# Altium schematic sheet Processor.SchDoc(Processor)
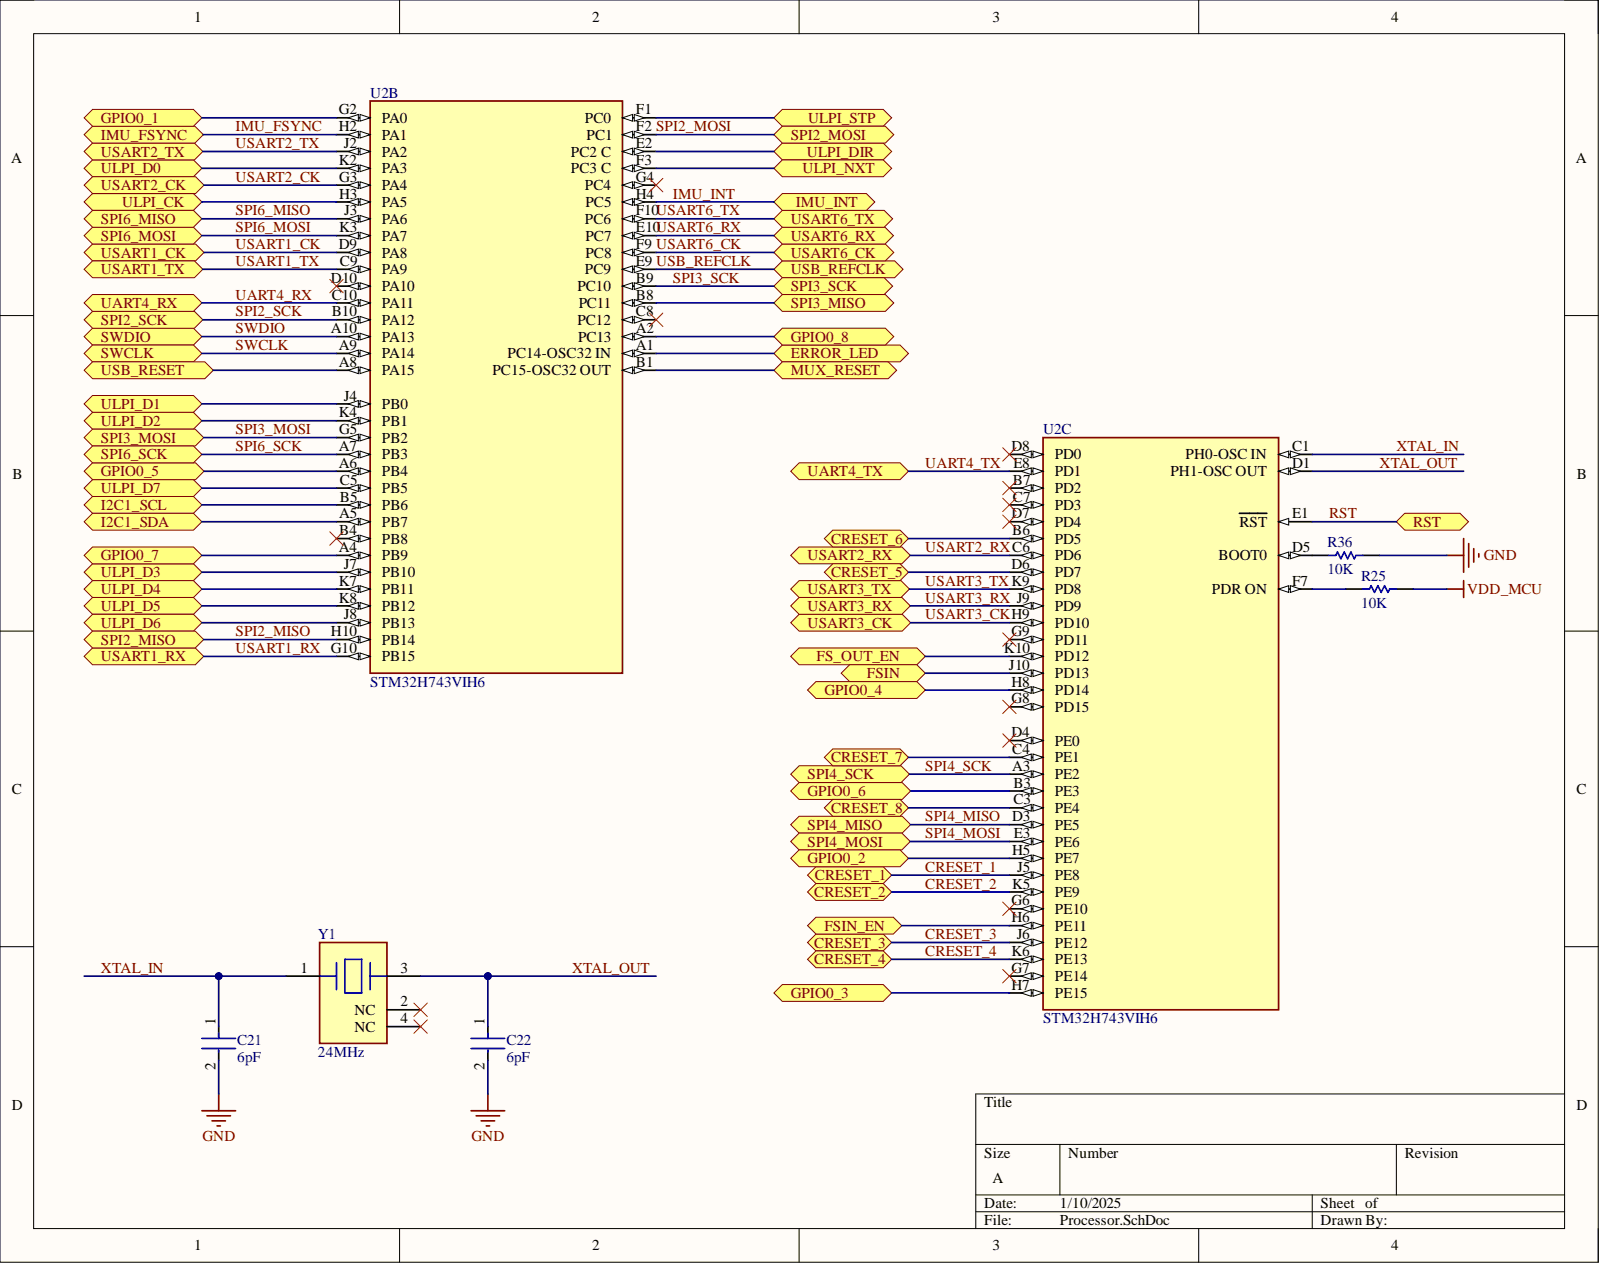
[diagram p0: Processor.SchDoc]

## Parts
C21  6pF
C22  6pF
R25  10K
R36  10K
U2  STM32H743VIH6  (parts B,C)
Y1  24MHz

## Ports
CRESET_1, CRESET_2, CRESET_3, CRESET_4, CRESET_5, CRESET_6, CRESET_7, CRESET_8, ERROR_LED, FS_OUT_EN, FSIN, FSIN_EN, GPIO0_1, GPIO0_2, GPIO0_3, GPIO0_4, GPIO0_5, GPIO0_6, GPIO0_7, GPIO0_8, I2C1_SCL, I2C1_SDA, IMU_FSYNC, IMU_INT, MUX_RESET, RST, SPI2_MISO, SPI2_MOSI, SPI2_SCK, SPI3_MISO, SPI3_MOSI, SPI3_SCK, SPI4_MISO, SPI4_MOSI, SPI4_SCK, SPI6_MISO, SPI6_MOSI, SPI6_SCK, SWCLK, SWDIO, UART4_RX, UART4_TX, ULPI_CK, ULPI_D0, ULPI_D1, ULPI_D2, ULPI_D3, ULPI_D4, ULPI_D5, ULPI_D6, ULPI_D7, ULPI_DIR, ULPI_NXT, ULPI_STP, USART1_CK, USART1_RX, USART1_TX, USART2_CK, USART2_RX, USART2_TX, USART3_CK, USART3_RX, USART3_TX, USART6_CK, USART6_RX, USART6_TX, USB_REFCLK, USB_RESET

## Nets
GND: C21.2 C22.2 R36.1
VDD_MCU: R25.1
CRESET_1: U2.J5(PE8) ; port CRESET_1
CRESET_2: U2.K5(PE9) ; port CRESET_2
CRESET_3: U2.J6(PE12) ; port CRESET_3
CRESET_4: U2.K6(PE13) ; port CRESET_4
CRESET_5: U2.D6(PD7) ; port CRESET_5
CRESET_6: U2.B6(PD5) ; port CRESET_6
CRESET_7: U2.C4(PE1) ; port CRESET_7
CRESET_8: U2.C3(PE4) ; port CRESET_8
ERROR_LED: U2.A1(PC14-OSC32_IN) ; port ERROR_LED
FS_OUT_EN: U2.K10(PD12) ; port FS_OUT_EN
FSIN: U2.J10(PD13) ; port FSIN
FSIN_EN: U2.H6(PE11) ; port FSIN_EN
GPIO0_1: U2.G2(PA0) ; port GPIO0_1
GPIO0_2: U2.H5(PE7) ; port GPIO0_2
GPIO0_3: U2.H7(PE15) ; port GPIO0_3
GPIO0_4: U2.H8(PD14) ; port GPIO0_4
GPIO0_5: U2.A6(PB4) ; port GPIO0_5
GPIO0_6: U2.B3(PE3) ; port GPIO0_6
GPIO0_7: U2.A4(PB9) ; port GPIO0_7
GPIO0_8: U2.A2(PC13) ; port GPIO0_8
I2C1_SCL: U2.B5(PB6) ; port I2C1_SCL
I2C1_SDA: U2.A5(PB7) ; port I2C1_SDA
IMU_FSYNC: U2.H2(PA1) ; port IMU_FSYNC
IMU_INT: U2.H4(PC5) ; port IMU_INT
MUX_RESET: U2.B1(PC15-OSC32_OUT) ; port MUX_RESET
RST: U2.E1(RST) ; port RST
SPI2_MISO: U2.H10(PB14) ; port SPI2_MISO
SPI2_MOSI: U2.F2(PC1) ; port SPI2_MOSI
SPI2_SCK: U2.B10(PA12) ; port SPI2_SCK
SPI3_MISO: U2.B8(PC11) ; port SPI3_MISO
SPI3_MOSI: U2.G5(PB2) ; port SPI3_MOSI
SPI3_SCK: U2.B9(PC10) ; port SPI3_SCK
SPI4_MISO: U2.D3(PE5) ; port SPI4_MISO
SPI4_MOSI: U2.E3(PE6) ; port SPI4_MOSI
SPI4_SCK: U2.A3(PE2) ; port SPI4_SCK
SPI6_MISO: U2.J3(PA6) ; port SPI6_MISO
SPI6_MOSI: U2.K3(PA7) ; port SPI6_MOSI
SPI6_SCK: U2.A7(PB3) ; port SPI6_SCK
SWCLK: U2.A9(PA14) ; port SWCLK
SWDIO: U2.A10(PA13) ; port SWDIO
UART4_RX: U2.C10(PA11) ; port UART4_RX
UART4_TX: U2.E8(PD1) ; port UART4_TX
ULPI_CK: U2.H3(PA5) ; port ULPI_CK
ULPI_D0: U2.K2(PA3) ; port ULPI_D0
ULPI_D1: U2.J4(PB0) ; port ULPI_D1
ULPI_D2: U2.K4(PB1) ; port ULPI_D2
ULPI_D3: U2.J7(PB10) ; port ULPI_D3
ULPI_D4: U2.K7(PB11) ; port ULPI_D4
ULPI_D5: U2.K8(PB12) ; port ULPI_D5
ULPI_D6: U2.J8(PB13) ; port ULPI_D6
ULPI_D7: U2.C5(PB5) ; port ULPI_D7
ULPI_DIR: U2.E2(PC2_C) ; port ULPI_DIR
ULPI_NXT: U2.F3(PC3_C) ; port ULPI_NXT
ULPI_STP: U2.F1(PC0) ; port ULPI_STP
USART1_CK: U2.D9(PA8) ; port USART1_CK
USART1_RX: U2.G10(PB15) ; port USART1_RX
USART1_TX: U2.C9(PA9) ; port USART1_TX
USART2_CK: U2.G3(PA4) ; port USART2_CK
USART2_RX: U2.C6(PD6) ; port USART2_RX
USART2_TX: U2.J2(PA2) ; port USART2_TX
USART3_CK: U2.H9(PD10) ; port USART3_CK
USART3_RX: U2.J9(PD9) ; port USART3_RX
USART3_TX: U2.K9(PD8) ; port USART3_TX
USART6_CK: U2.F9(PC8) ; port USART6_CK
USART6_RX: U2.E10(PC7) ; port USART6_RX
USART6_TX: U2.F10(PC6) ; port USART6_TX
USB_REFCLK: U2.E9(PC9) ; port USB_REFCLK
USB_RESET: U2.A8(PA15) ; port USB_RESET
XTAL_IN: C21.1 U2.C1(PH0-OSC_IN) Y1.1
XTAL_OUT: C22.1 U2.D1(PH1-OSC_OUT) Y1.3
NetR25_2: R25.2 U2.F7(PDR_ON)
NetR36_2: R36.2 U2.D5(BOOT0)

Not connected: U2 B4(PB8) B7(PD2) C7(PD3) C8(PC12) D4(PE0) D7(PD4) D8(PD0) D10(PA10) G4(PC4) G6(PE10) G7(PE14) G8(PD15) G9(PD11); Y1 2(NC) 4(NC)

## Library data of the parts
C21 C22 = CMP-2008-03869-2: Multi-Layer Ceramic Capacitor 6pF C0G  ±0.25pF 0402 Paper T/R; Voltage: 50V; Capacitance: 6pF; Case Code (Imperial): 0402; Case Code (Metric): 1005; Case/Package: 0402; Component Kind: Standard; Depth: 0.5mm; Dielectric: C0G; Height: 0.5mm; Lead Free: Lead Free; Length: 1mm; Library Name: Altium Content Vault; Max Operating Temperature: 125°C; Min Operating Temperature: -55°C; Mount: Surface Mount; Package Quantity: 10000; Packaging: Tape and Reel; Radiation Hardening: No; Termination: SMD/SMT; Thickness: 0.022inch; Voltage Rating: 50V; Voltage Rating (DC): 50V; Width: 0.02inch
R25 R36 = CMP-2002-07353-1: Manufacturer: Yageo / Phycomp; Part Number: RC0402FR-0710KL; Component Kind: Standard; Library Name: Altium Content Vault
U2 = CMP-12105-000020-1: HIGH-PERFORMANCE AND DSP WITH DP; Manufacturer: STMicroelectronics; Core Architecture: ARM Cortex-M7; Data Bus Width: 32bits; Frequency: 480MHz; Interface: I2C,SPI,UART,USART,CAN,USB,Ethernet,I2S,SMBus; Max Junction Temp: +125°C; Max Supply Voltage: 3.6V; Memory Size: 2MBytes; Memory Type: FLASH; Min Supply Voltage: 1.71V; Number Of A/D Converters: 3; Number Of Adc Channels: 36; Number Of Cores: 1; Number Of I2C Channels: 4; Number Of I/Os: 82; Number Of Pwm Channels: 2; Number Of Spi Channels: 6; Number Of Timers/Counters: 22; Number Of Uart Channels: 9; Ram Size: 1MBytes; Standoff Height: 0.15mm; Ambient Temperature Range High: +85°C; Ambient Temperature Range Low: -40°C; Automotive: No; Category: IC; Component Kind: Standard; ComponentLink2Description: Datasheet; ComponentLink2URL: https://www.st.com/resource/en/datasheet/stm32h743vi.pdf; Device Class L1: Integrated Circuits (ICs); Device Class L2: Embedded Processors and Controllers; Device Class L3: Microcontrollers; Digikey Description: HIGH-PERFORMANCE AND DSP WITH DP; Height: 1.1mm; Ipc Land Pattern Name: BGA100C80P10X10_800X800X110; Lead Free: Yes; Library Name: Altium Content Vault; Manufacturer Part Number: STM32H743VIH6; Mouser Description: MCU 32-Bit STM32H743VI ARM Cortex M7 RISC 2MB Flash 1.8V/2.5V/3.3V 100-Pin TFBGA Tray; Number Of Ethernet Channels: 1; Number Of Usb Channels: 1; Octopart: https://octopart.com/stm32h743vih6-stmicroelectronics-93590710; Package: TFBGA100-A08Q; RoHS: TRUE; Temperature: -40°C to +85°C; Temperature Range High: +85°C; Temperature Range Low: -40°C
Y1 = CMP-06054-000017-1: CRYSTAL 24.0000MHZ 6PF SMD; ESR (Equivalent Series Resistance): 150R; Frequency Stability: 40ppm; Frequency Tolerance: 30ppm; Case/Package: SMD/SMT; Component Kind: Standard; Depth: 1.6mm; Frequency: 24MHz; Height: 0.028inch; Lead Free: Lead Free; Length: 2mm; Library Name: Altium Content Vault; Load Capacitance: 6pF; Max Operating Temperature: 85°C; Min Operating Temperature: -30°C; Mount: Surface Mount; Number of Pins: 4; Operating Frequency: 24 MHz; Package Quantity: 1; Packaging: Tape and Reel; REACH SVHC: No SVHC; RoHS Compliant: Yes; Series: XRCGB; Series Resistance: 150R; Termination: SMD/SMT; Tolerance: 30ppm; Width: 1.6mm
